# Altium schematic sheet Buck 5-12V.SchDoc
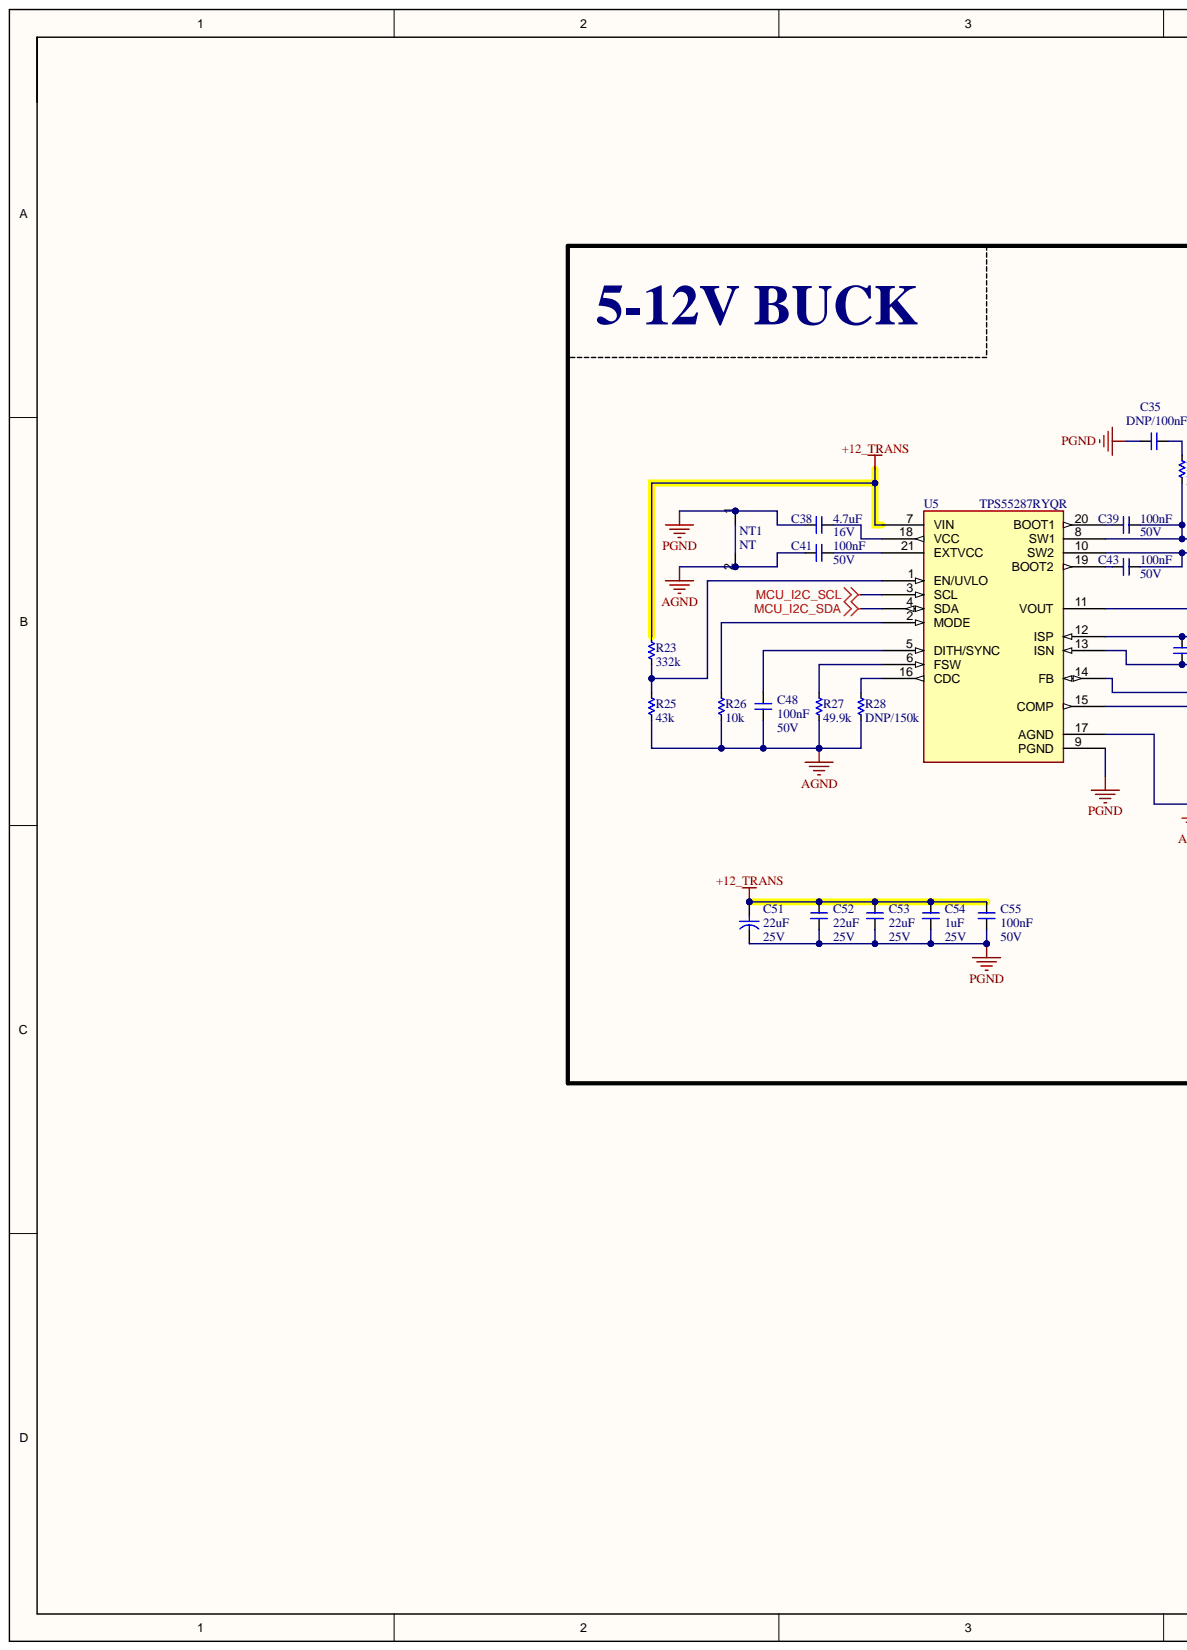
[diagram p0: Buck 5-12V.SchDoc, left]
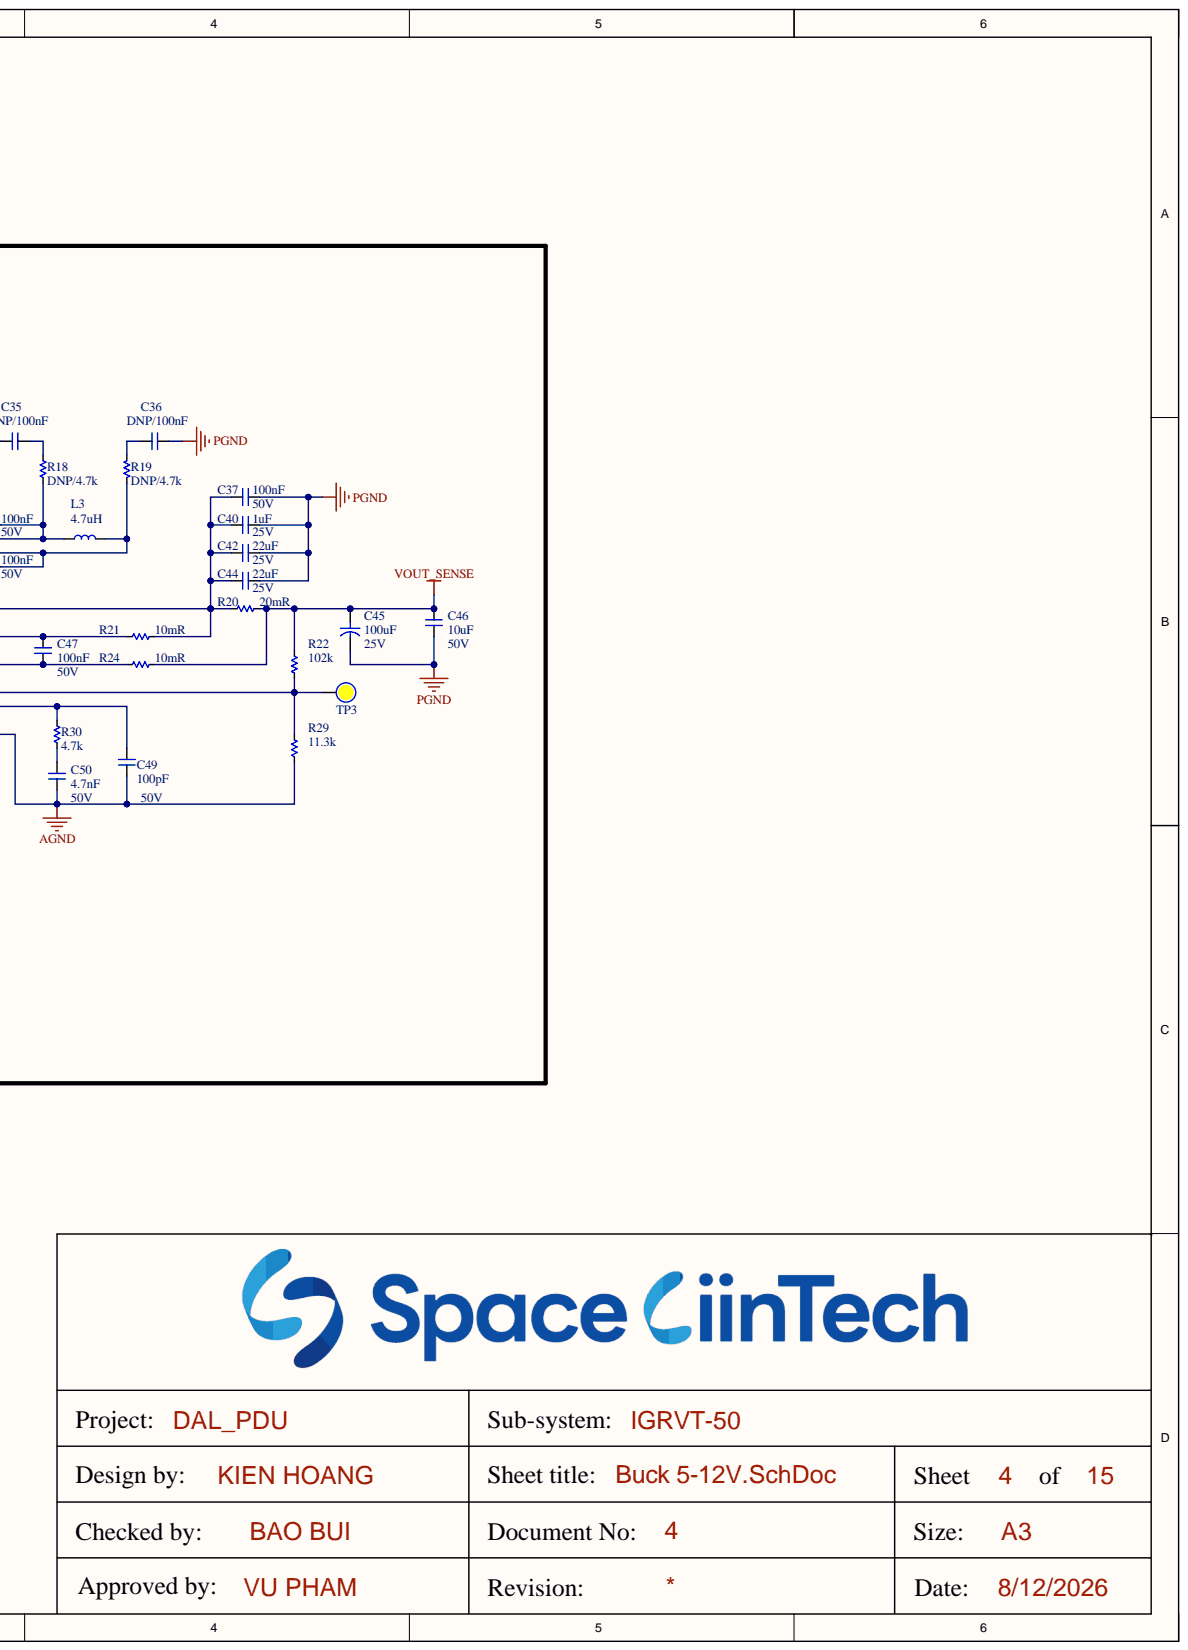
[diagram p1: Buck 5-12V.SchDoc, right]

## Parts
C35  DNP/100nF  [CAP_C_2012X110]
C36  DNP/100nF  [CAP_C_2012X110]
C37  100nF  Voltage: 50V  [CAP_C_1005X60]
C38  4.7uF  Voltage: 16V  [CAP_C_1608X80]
C39  100nF  Voltage: 50V  [CAP_C_1608X80]
C40  1uF  Voltage: 25V  [CAP_C_1608X80]
C41  100nF  Voltage: 50V  [CAP_C_1608X80]
C42  22uF  Voltage: 25V  [CAP_C_2012X110]
C43  100nF  Voltage: 50V  [CAP_C_1608X80]
C44  22uF  Voltage: 25V  [CAP_C_2012X110]
C45  100uF  Voltage: 25V  [C SMD TAN 2917 (E)]
C46  10uF  Voltage: 50V  [CAP_C_3216X140]
C47  100nF  Voltage: 50V  [CAP_C_1608X80]
C48  100nF  Voltage: 50V  [CAP_C_1608X80]
C49  100pF  Voltage: 50V  [CAP_C_1608X80]
C50  4.7nF  Voltage: 50V  [CAP_C_1608X80]
C51  22uF  [C SMD TAN 2312 (C)]
C52  22uF  Voltage: 25V  [CAP_C_2012X110]
C53  22uF  Voltage: 25V  [CAP_C_2012X110]
C54  1uF  Voltage: 25V  [CAP_C_1608X80]
C55  100nF  Voltage: 50V  [CAP_C_1005X60]
L3  4.7uH  [Inductor SMD 6x5.7x5]
NT1  NT  [NETTIE_SMD]  (net tie)
R18  DNP/4.7k  [RES_C_3216X70]
R19  DNP/4.7k  [RES_C_3216X70]
R20  20mR  [RES_C_6331X65_N]
R21  10mR  [RES_C_1608X60]
R22  102k  [RES_C_1608X60]
R23  332k  [RES_C_1608X60]
R24  10mR  [RES_C_1608X60]
R25  43k  [RES_C_1608X60]
R26  10k  [RES_C_1608X60]
R27  49.9k  [RES_C_1608X60]
R28  DNP/150k  [RES_C_1608X60]
R29  11.3k  [RES_C_1608X60]
R30  4.7k  [RES_C_1608X60]
TP3  (no value)  [TP_1mm_SMD]  (no BOM)
U5  TPS55287RYQR  [VQFN-HR-21]

## Nets
+12_TRANS: C51.1 C52.2 C53.2 C54.2 C55.2 R23.2 U5.7(VIN)
AGND: C41.1 C48.1 C49.1 C50.1 NT1.2 R25.2 R26.1 R27.1 R28.1 R29.1 U5.17(AGND)
MCU_I2C_SCL: U5.3(SCL)
MCU_I2C_SDA: U5.4(SDA)
PGND: C35.2 C36.2 C37.1 C38.1 C40.1 C42.1 C44.1 C45.2 C46.1 C51.2 C52.1 C53.1 C54.1 C55.1 NT1.1 U5.9(PGND)
VOUT_SENSE: C45.1 C46.2 R20.1 R22.2 R24.1
NetC35_1: C35.1 R18.1
NetC36_1: C36.1 R19.2
NetC37_2: C37.2 C40.2 C42.2 C44.2 R20.2 R21.1 U5.11(VOUT)
NetC38_2: C38.2 U5.18(VCC)
NetC39_1: C39.1 L3.1 R18.2 U5.8(SW1)
NetC39_2: C39.2 U5.20(BOOT1)
NetC41_2: C41.2 U5.21(EXTVCC)
NetC43_1: C43.1 L3.2 R19.1 U5.10(SW2)
NetC43_2: C43.2 U5.19(BOOT2)
NetC47_1: C47.1 R21.2 U5.12(ISP)
NetC47_2: C47.2 R24.2 U5.13(ISN)
NetC48_2: C48.2 U5.5(DITH/SYNC)
NetC49_2: C49.2 R30.2 U5.15(COMP)
NetC50_2: C50.2 R30.1
NetR22_1: R22.1 R29.2 TP3.1 U5.14(FB)
NetR23_1: R23.1 R25.1 U5.1(EN/UVLO)
NetR26_2: R26.2 U5.2(MODE)
NetR27_2: R27.2 U5.6(FSW)
NetR28_2: R28.2 U5.16(CDC)

## Library data of the parts
C35-C44 C46-C50 C52-C55 = _CAP_C_2: CAP; Component Kind: Standard; Library Name: LABLIB.SchLib
C45 C51 = Capacitor: Capacitor pol; Component Kind: Standard; Library Name: KIENASIY123_LIB.IntLib
L3 = Inductor: Component Kind: Standard; Description: Inductor; Library Name: chipfc_libs.SchLib
NT1 = NetTie_SMD: Net Tie SMD; Library Name: KIENASIY123_LIB.IntLib
R18-R30 = _RES_B_2_2: RES; Component Kind: Standard; Library Name: LABLIB.SchLib
TP3 = Test Point: Solder: NF; Description: Test Point; Library Name: LABLIB.SchLib
U5 = TPS55289-Q1: Component Kind: Standard; Library Name: KIENASIY123_LIB.IntLib
